# Altium schematic sheet Protection_logic.SchDoc(Protection_logic)
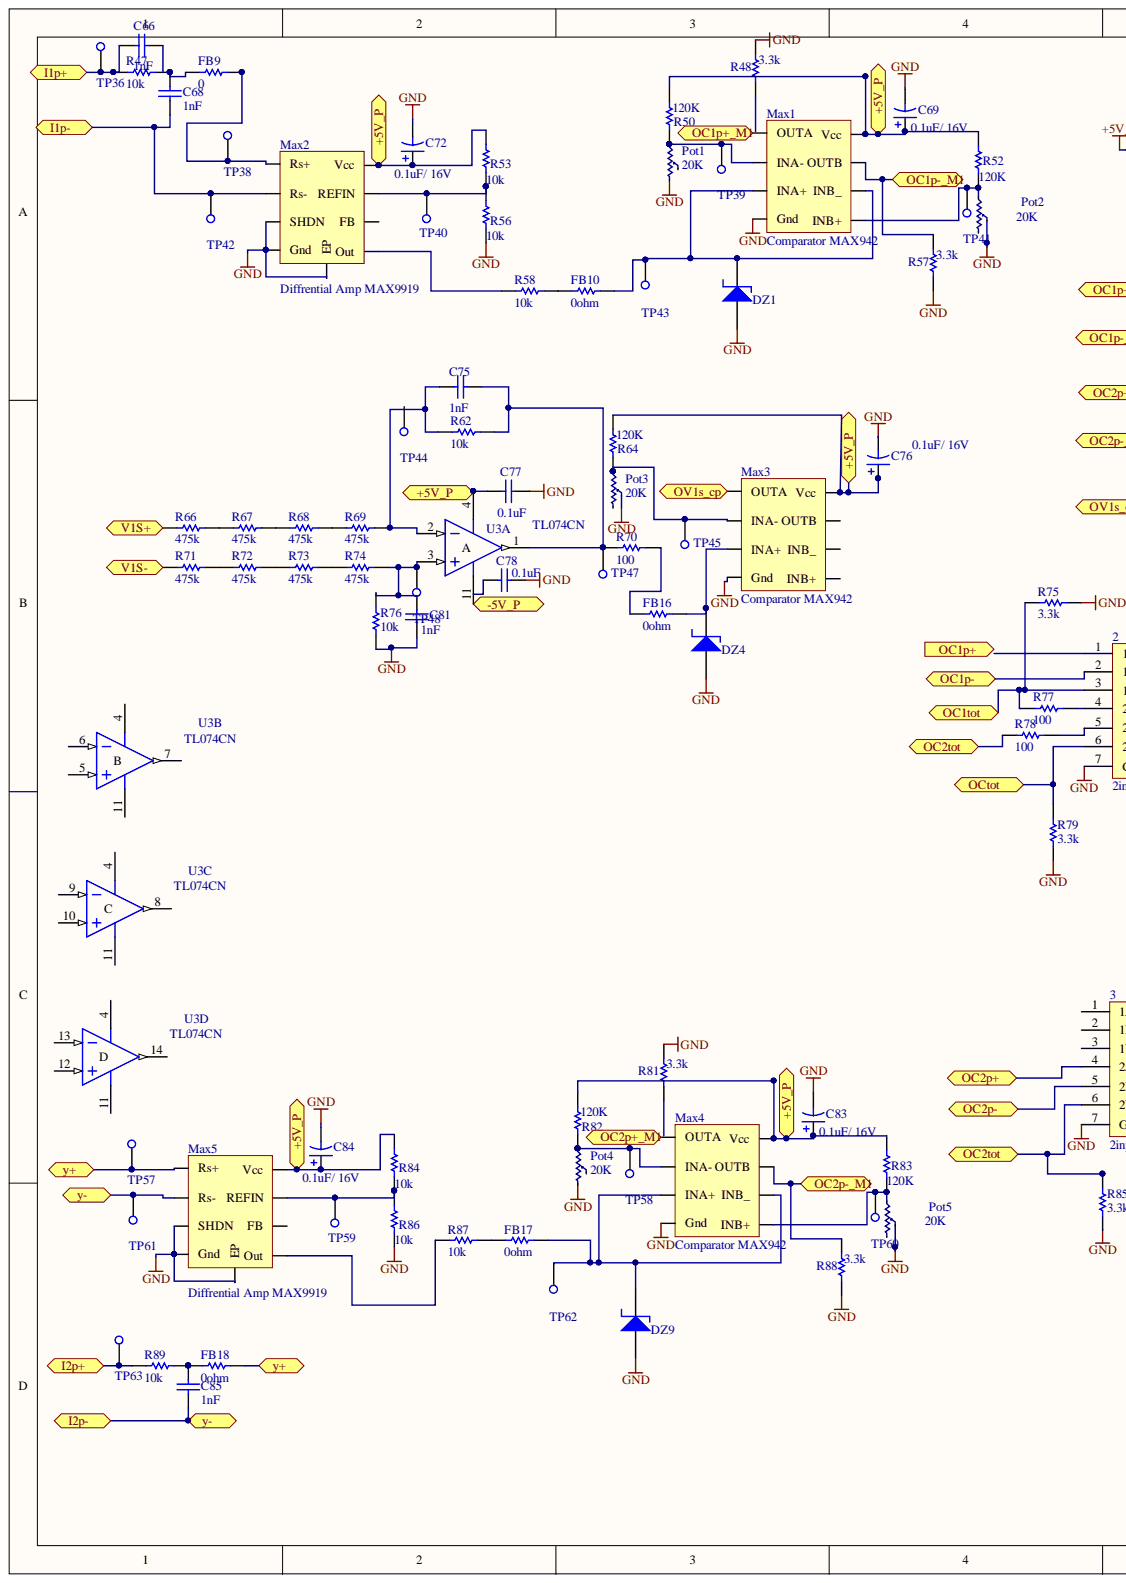
[diagram p0: Protection_logic.SchDoc, left]
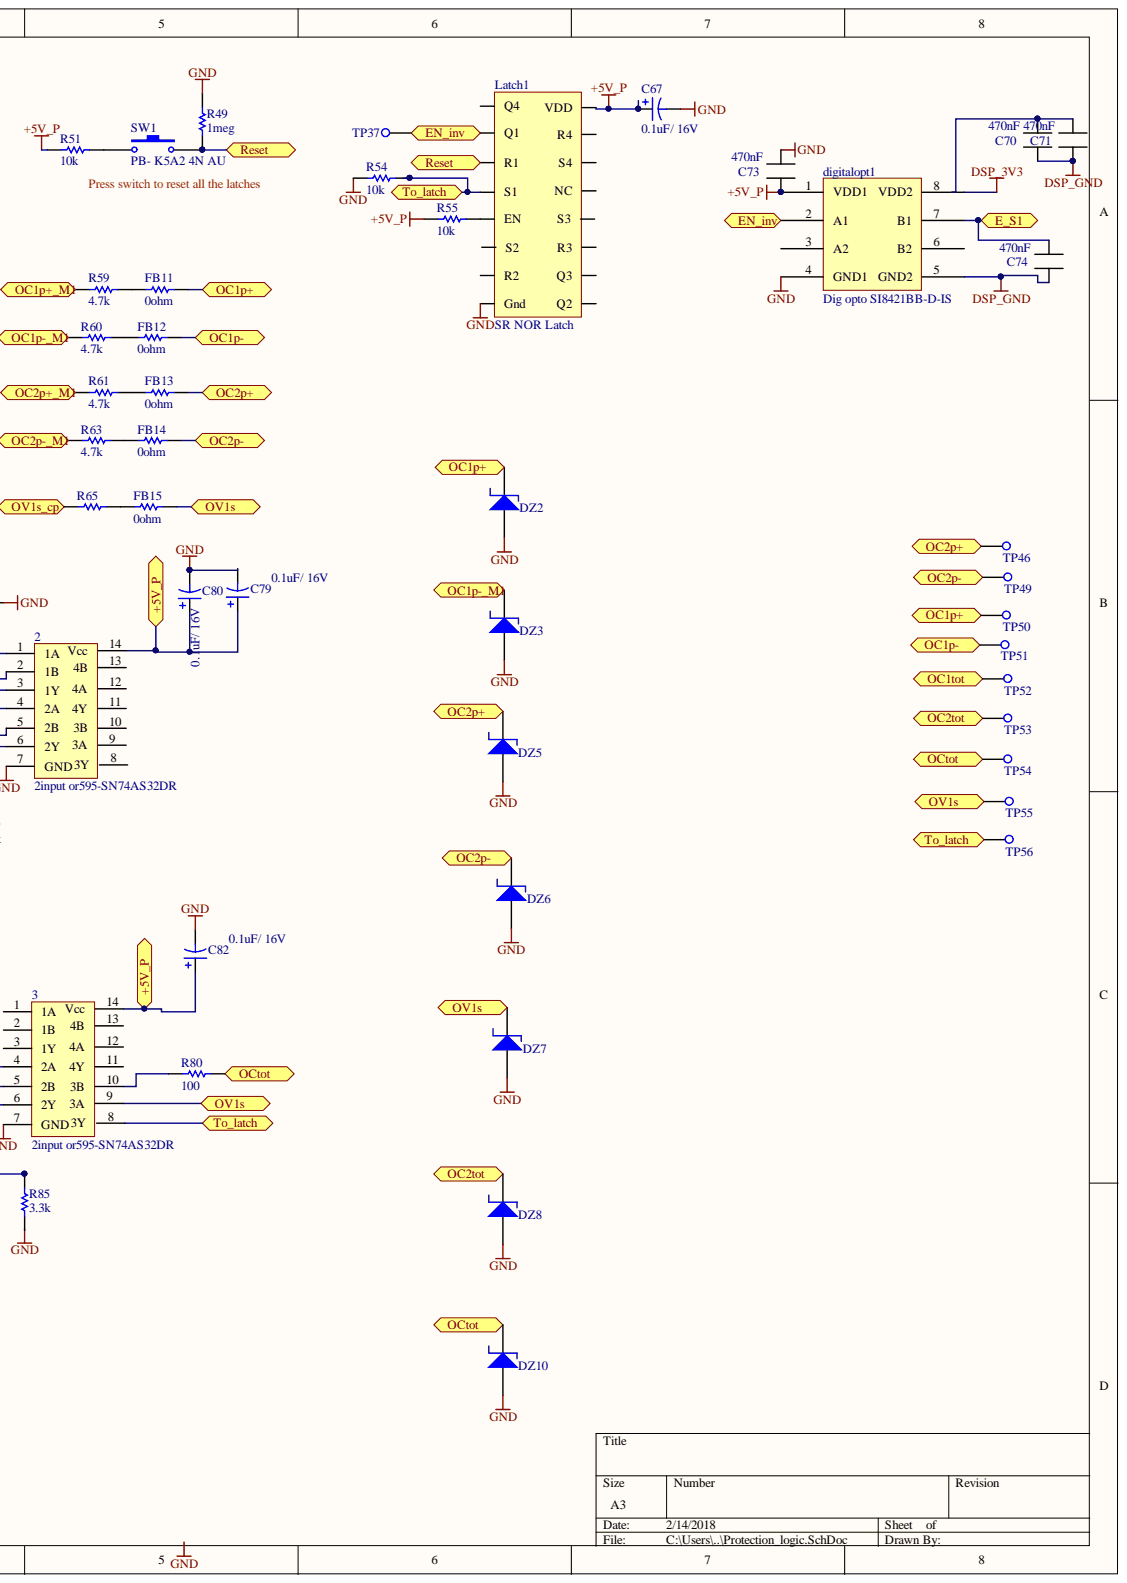
[diagram p1: Protection_logic.SchDoc, right]

## Parts
2  2input or595-SN74AS32DR  [2inputor]
3  2input or595-SN74AS32DR  [2inputor]
C66  value 1nF  [C1206]  (hidden comment: 16V)
C67  value 0.1uF/ 16V  [C0805]  (hidden comment: Cap Pol3)
C68  value 1nF  [C1206]  (hidden comment: 16V)
C69  value 0.1uF/ 16V  [C0805]  (hidden comment: Cap Pol3)
C70  100nF  [Cap_SMD]  (value not drawn)
C71  100nF  [Cap_SMD]  (value not drawn)
C72  value 0.1uF/ 16V  [C0805]  (hidden comment: Cap Pol3)
C73  100nF  [Cap_SMD]  (value not drawn)
C74  100nF  [Cap_SMD]  (value not drawn)
C75  value 1nF  [C1206]  (hidden comment: 16V)
C76  value 0.1uF/ 16V  [C0805]  (hidden comment: Cap Pol3)
C77  value 0.1uF  [C1206]  (hidden comment: 16V)
C78  value 0.1uF  [C1206]  (hidden comment: 16V)
C79  value 0.1uF/ 16V  [C0805]  (hidden comment: Cap Pol3)
C80  value 0.1uF/ 16V  [C0805]  (hidden comment: Cap Pol3)
C81  value 1nF  [C1206]  (hidden comment: 16V)
C82  value 0.1uF/ 16V  [C0805]  (hidden comment: Cap Pol3)
C83  value 0.1uF/ 16V  [C0805]  (hidden comment: Cap Pol3)
C84  value 0.1uF/ 16V  [C0805]  (hidden comment: Cap Pol3)
C85  value 1nF  [C1206]  (hidden comment: 16V)
digitalopt1  Dig opto SI8421BB-D-IS  [SO8 TS27l2]
DZ1  MMSZ5221BS  [MMSZ5221BS]  (value not drawn)
DZ2  MMSZ5221BS  [MMSZ5221BS]  (value not drawn)
DZ3  MMSZ5221BS  [MMSZ5221BS]  (value not drawn)
DZ4  MMSZ5221BS  [MMSZ5221BS]  (value not drawn)
DZ5  MMSZ5221BS  [MMSZ5221BS]  (value not drawn)
DZ6  MMSZ5221BS  [MMSZ5221BS]  (value not drawn)
DZ7  MMSZ5221BS  [MMSZ5221BS]  (value not drawn)
DZ8  MMSZ5221BS  [MMSZ5221BS]  (value not drawn)
DZ9  MMSZ5221BS  [MMSZ5221BS]  (value not drawn)
DZ10  MMSZ5221BS  [MMSZ5221BS]  (value not drawn)
FB9  res_smd  [res_smd]  (value not drawn)
FB10  res_smd  [res_smd]  (value not drawn)
FB11  res_smd  [res_smd]  (value not drawn)
FB12  res_smd  [res_smd]  (value not drawn)
FB13  res_smd  [res_smd]  (value not drawn)
FB14  res_smd  [res_smd]  (value not drawn)
FB15  res_smd  [res_smd]  (value not drawn)
FB16  res_smd  [res_smd]  (value not drawn)
FB17  res_smd  [res_smd]  (value not drawn)
FB18  res_smd  [res_smd]  (value not drawn)
Latch1  SR NOR Latch  [SR latch]
Max1  Comparator MAX942  [SOIC 8_ no EP]
Max2  Diffrential Amp MAX9919  [SOIC127P600X168_HS-9N]
Max3  Comparator MAX942  [SOIC 8_ no EP]
Max4  Comparator MAX942  [SOIC 8_ no EP]
Max5  Diffrential Amp MAX9919  [SOIC127P600X168_HS-9N]
Pot1  20K  [Pot]
Pot2  20K  [Pot]
Pot3  20K  [Pot]
Pot4  20K  [Pot]
Pot5  20K  [Pot]
R47  res_smd  [res_smd]  (value not drawn)
R48  res_smd  [res_smd]  (value not drawn)
R49  res_smd  [res_smd]  (value not drawn)
R50  res_smd  [res_smd]  (value not drawn)
R51  res_smd  [res_smd]  (value not drawn)
R52  res_smd  [res_smd]  (value not drawn)
R53  res_smd  [res_smd]  (value not drawn)
R54  res_smd  [res_smd]  (value not drawn)
R55  res_smd  [res_smd]  (value not drawn)
R56  res_smd  [res_smd]  (value not drawn)
R57  res_smd  [res_smd]  (value not drawn)
R58  res_smd  [res_smd]  (value not drawn)
R59  res_smd  [res_smd]  (value not drawn)
R60  res_smd  [res_smd]  (value not drawn)
R61  res_smd  [res_smd]  (value not drawn)
R62  res_smd  [res_smd]  (value not drawn)
R63  res_smd  [res_smd]  (value not drawn)
R64  res_smd  [res_smd]  (value not drawn)
R65  res_smd  [res_smd]  (value not drawn)
R66  res_smd  [res_smd]  (value not drawn)
R67  res_smd  [res_smd]  (value not drawn)
R68  res_smd  [res_smd]  (value not drawn)
R69  res_smd  [res_smd]  (value not drawn)
R70  res_smd  [res_smd]  (value not drawn)
R71  res_smd  [res_smd]  (value not drawn)
R72  res_smd  [res_smd]  (value not drawn)
R73  res_smd  [res_smd]  (value not drawn)
R74  res_smd  [res_smd]  (value not drawn)
R75  res_smd  [res_smd]  (value not drawn)
R76  res_smd  [res_smd]  (value not drawn)
R77  res_smd  [res_smd]  (value not drawn)
R78  res_smd  [res_smd]  (value not drawn)
R79  res_smd  [res_smd]  (value not drawn)
R80  res_smd  [res_smd]  (value not drawn)
R81  res_smd  [res_smd]  (value not drawn)
R82  res_smd  [res_smd]  (value not drawn)
R83  res_smd  [res_smd]  (value not drawn)
R84  res_smd  [res_smd]  (value not drawn)
R85  res_smd  [res_smd]  (value not drawn)
R86  res_smd  [res_smd]  (value not drawn)
R87  res_smd  [res_smd]  (value not drawn)
R88  res_smd  [res_smd]  (value not drawn)
R89  res_smd  [res_smd]  (value not drawn)
SW1  PB- K5A2 4N AU  [Push Button _New]
TP36  Test Point  [Test Point]  (value not drawn)
TP37  Test Point  [Test Point]  (value not drawn)
TP38  Test Point  [Test Point]  (value not drawn)
TP39  Test Point  [Test Point]  (value not drawn)
TP40  Test Point  [Test Point]  (value not drawn)
TP41  Test Point  [Test Point]  (value not drawn)
TP42  Test Point  [Test Point]  (value not drawn)
TP43  Test Point  [Test Point]  (value not drawn)
TP44  Test Point  [Test Point]  (value not drawn)
TP45  Test Point  [Test Point]  (value not drawn)
TP46  Test Point  [Test Point]  (value not drawn)
TP47  Test Point  [Test Point]  (value not drawn)
TP48  Test Point  [Test Point]  (value not drawn)
TP49  Test Point  [Test Point]  (value not drawn)
TP50  Test Point  [Test Point]  (value not drawn)
TP51  Test Point  [Test Point]  (value not drawn)
TP52  Test Point  [Test Point]  (value not drawn)
TP53  Test Point  [Test Point]  (value not drawn)
TP54  Test Point  [Test Point]  (value not drawn)
TP55  Test Point  [Test Point]  (value not drawn)
TP56  Test Point  [Test Point]  (value not drawn)
TP57  Test Point  [Test Point]  (value not drawn)
TP58  Test Point  [Test Point]  (value not drawn)
TP59  Test Point  [Test Point]  (value not drawn)
TP60  Test Point  [Test Point]  (value not drawn)
TP61  Test Point  [Test Point]  (value not drawn)
TP62  Test Point  [Test Point]  (value not drawn)
TP63  Test Point  [Test Point]  (value not drawn)
U3  TL074CN  [DIP14]  (parts A,B,C,D)

## Ports
+5V_P, -5V_P, E_S1, EN_inv, I1p+, I1p-, I2p+, I2p-, OC1p+, OC1p+_M1, OC1p-, OC1p-_M1, OC1tot, OC2p+, OC2p+_M1, OC2p-, OC2p-_M1, OC2tot, OCtot, OV1s, OV1s_cp, Reset, To_latch, V1S+, V1S-, y+, y-

## Nets
+5V_P: C67.1 C73.2 digitalopt1.1(VDD1) Latch1.16(VDD) R51.2 R55.2
DSP_3V3: digitalopt1.8(VDD2)
DSP_GND: C70.2 C71.2 C74.2 digitalopt1.5(GND2)
GND: 2.7(GND) 3.7(GND) C67.2 C69.2 C72.2 C73.1 C76.2 C77.1 C78.1 C79.2 C80.2 C81.2 C82.2 C83.2 C84.2 digitalopt1.4(GND1) DZ1.2 DZ2.2 DZ3.2 DZ4.2 DZ5.2 DZ6.2 DZ7.2 DZ8.2 DZ9.2 DZ10.2 Latch1.8(Gnd) Max1.4(Gnd) Max2.3(SHDN) Max2.4(Gnd) Max2.9(EP) Max3.4(Gnd) Max4.4(Gnd) Max5.3(SHDN) Max5.4(Gnd) Max5.9(EP) Pot1.3 Pot2.2 Pot3.2 Pot4.3 Pot5.2 R48.2 R49.1 R54.2 R56.1 R57.1 R75.2 R76.2 R79.1 R81.2 R86.1 R88.1
E_S1: C74.1 digitalopt1.7(B1) ; port E_S1
EN_inv: digitalopt1.2(A1) Latch1.2(Q1) TP37.1 ; port EN_inv
OC1tot: 2.3(1Y) R75.1 R77.2 TP52.1 ; port OC1tot
OC2tot: 3.6(2Y) DZ8.1 R78.2 R85.2 TP53.1 ; port OC2tot
OCtot: 2.6(2Y) DZ10.1 R79.2 R80.1 TP54.1 ; port OCtot
OV1s: 3.9(3A) DZ7.1 FB15.1 TP55.1 ; port OV1s
OV1s_cp: Max3.1(OUTA) R65.2 ; port OV1s_cp
Reset: Latch1.3(R1) R49.2 SW1.2 ; port Reset
To_latch: 3.8(3Y) Latch1.4(S1) R54.1 TP56.1 ; port To_latch
Net2_2: 2.2(1B) FB12.1 TP51.1
Net2_4: 2.4(2A) R77.1
Net2_5: 2.5(2B) R78.1
Net2_14: 2.14(Vcc) 3.14(Vcc) C69.1 C72.1 C76.1 C77.2 C79.1 C80.1 C82.1 C83.1 C84.1 Max1.8(Vcc) Max2.8(Vcc) Max3.8(Vcc) Max4.8(Vcc) Max5.8(Vcc) R50.2 R52.2 R53.2 R64.2 R82.2 R83.2 R84.2 U3.4
Net3_4: 3.4(2A) DZ5.1 FB13.1 TP46.1
Net3_5: 3.5(2B) DZ6.1 FB14.1 TP49.1
Net3_10: 3.10(3B) R80.2
NetC66_1: C66.1 R47.2 TP36.1
NetC68_1: C68.1 FB9.2 R47.1
NetC68_2: C68.2 Max2.2(Rs-) TP42.1
NetC70_1: C70.1 C71.1
NetC75_1: C75.1 R62.1 R70.2 TP47.1 U3.1
NetC75_2: C75.2 R62.2 R69.1 U3.2
NetC81_1: C81.1 R74.1 R76.1 TP48.1 U3.3
NetC85_1: C85.1 FB18.2 R89.1
NetC85_2: C85.2 Max5.2(Rs-) TP61.1
NetDZ1_1: DZ1.1 FB10.1 Max1.3(INA+) Max1.6(INB_) TP43.1
NetDZ2_1: DZ2.1 FB11.1 TP50.1
NetDZ3_1: DZ3.1 Max1.7(OUTB) R57.2 R60.2
NetDZ4_1: DZ4.1 FB16.1 Max3.3(INA+)
NetDZ9_1: DZ9.1 FB17.1 Max4.6(INB_) TP62.1
NetFB9_1: FB9.1 Max2.1(Rs+) TP38.1
NetFB10_2: FB10.2 R58.1
NetFB11_2: FB11.2 R59.1
NetFB12_2: FB12.2 R60.1
NetFB13_2: FB13.2 R61.1
NetFB14_2: FB14.2 R63.1
NetFB15_2: FB15.2 R65.1
NetFB16_2: FB16.2 R70.1
NetFB17_2: FB17.2 R87.1
NetFB18_1: FB18.1 Max5.1(Rs+) TP57.1
NetLatch1_5: Latch1.5(EN) R55.1
NetMax1_1: Max1.1(OUTA) R59.2
NetMax1_2: Max1.2(INA-) Pot1.1 R50.1 TP39.1
NetMax1_5: Max1.5(INB+) Pot2.1 R52.1 TP41.1
NetMax2_5: Max2.5(Out) R58.2
NetMax2_7: Max2.7(REFIN) R53.1 R56.2 TP40.1
NetMax3_2: Max3.2(INA-) Pot3.1 R64.1 TP45.1
NetMax4_1: Max4.1(OUTA) R61.2
NetMax4_2: Max4.2(INA-) Pot4.1 R82.1 TP58.1
NetMax4_5: Max4.5(INB+) Pot5.1 R83.1 TP60.1
NetMax4_7: Max4.7(OUTB) R63.2 R88.2
NetMax5_5: Max5.5(Out) R87.2
NetMax5_7: Max5.7(REFIN) R84.1 R86.2 TP59.1
NetR51_1: R51.1 SW1.1
NetR66_1: R66.1 R67.2
NetR66_2: R66.2
NetR67_1: R67.1 R68.2
NetR68_1: R68.1 R69.2
NetR71_1: R71.1 R72.2
NetR71_2: R71.2
NetR72_1: R72.1 R73.2
NetR73_1: R73.1 R74.2
NetR89_2: R89.2 TP63.1
NetU3_11: U3.11

Not connected: 2 1(1A) 8(3Y) 9(3A) 10(3B) 11(4Y) 12(4A) 13(4B); 3 1(1A) 2(1B) 3(1Y) 11(4Y) 12(4A) 13(4B); C66 2; C78 2; digitalopt1 3(A2) 6(B2); Latch1 1(Q4) 6(S2) 7(R2) 9(Q2) 10(Q3) 11(R3) 12(S3) 13(NC) 14(S4) 15(R4); Max2 6(FB); Max3 5(INB+) 6(INB_) 7(OUTB); Max4 3(INA+); Max5 6(FB); Pot1 2; Pot2 3; Pot3 3; Pot4 2; Pot5 3; R48 1; R81 1; R85 1; TP44 1; U3 5 6 7 8 9 10 12 13 14

## Library data of the parts
2 3 = 2 input OR: Component Kind: Standard; Library Name: Gan_cuk converter.SchLib
C66 C68 C75 C77 C78 C81 C85 = Cap Semi: Capacitor (Semiconductor SIM Model); Simulation: CAP; Signal Integrity: Cap Semi; Published: 8-Jun-2000; PackageReference: C1206; PackageDescription: Chip Capacitor; Library Name: Miscellaneous Devices.SchLib; LatestRevisionNote: IPC-7351 Footprint Added.; LatestRevisionDate: 29-May-2009; Component Kind: Standard; Publisher: Altium Limited
C67 C72 C76 C79 C80 C82 C84 = Cap Pol3: Polarized Capacitor (Surface Mount); Simulation: CAP; Signal Integrity: Cap Pol; Published: 8-Jun-2000; PackageReference: C0805; PackageDescription: Chip Capacitor; Library Name: Miscellaneous Devices.SchLib; LatestRevisionNote: IPC-7351 Footprint Added.; LatestRevisionDate: 29-May-2009; Component Kind: Standard; Publisher: Altium Limited
C69 C83 = Cap Pol3: Polarized Capacitor (Surface Mount); Value: 0.1uF/ 16V; Simulation: CAP; Signal Integrity: Cap Pol; Published: 8-Jun-2000; PackageReference: C0805; PackageDescription: Chip Capacitor; Library Name: Miscellaneous Devices.SchLib; LatestRevisionNote: IPC-7351 Footprint Added.; LatestRevisionDate: 29-May-2009; Component Kind: Standard; Publisher: Altium Limited
C70 C71 C73 C74 = Cap_SMD: Library Name: Schlib1.SchLib; Component Kind: Standard; : 470nF
digitalopt1 = Digital Isolator: Library Name: control Board.SchLib; Component Kind: Standard
FB9-FB18 R47-R89 = res_smd: smd_res; Library Name: Schlib1.SchLib; Component Kind: Standard
  FB9: : 0
  FB10-FB18: : 0ohm
  R47 R51 R53-R56 R58 R62 R76 R84 R86 R87 R89: : 10k
  R48 R57 R75 R79 R81 R85 R88: : 3.3k
  R49: : 1meg
  R50 R52 R64 R82 R83: : 120K
  R59-R61 R63: : 4.7k
  R66-R69 R71-R74: : 475k
  R70 R77 R78 R80: : 100
Latch1 = SR Latch: CD4043BDR; Component Kind: Standard; Library Name: Gan_cuk converter.SchLib
Max1 Max3 Max4 = Max942: MAX942ESA+; Component Kind: Standard; Library Name: Gan_cuk converter.SchLib
Max2 Max5 = Max9919: MAX9919NASA+; Component Kind: Standard; Library Name: Gan_cuk converter.SchLib
Pot1-Pot5 = TRIM POT: 3296W-1-253LF; Component Kind: Standard; Library Name: Gan_cuk converter.SchLib
SW1 = SW-PB: Switch; Revision: July-2002: Re-released for DXP Platform.; Published: 24-Mar-1999; Library Name: control Board.SchLib; Component Kind: Standard; Publisher: Altium Limited
U3 = TL074J: Low-Noise JFET-Input Operational Amplifier; Simulation: TL074; Published: 16-Jan-2001; PCB3D: J014; PackageVersion: Jun-1999; PackageReference: J014; PackageDescription: DIP; 14 Leads; Row Spacing 7.62 mm; Pitch 2.54 mm; LatestRevisionNote: Stylized 3D Model Added.; LatestRevisionDate: 04-Mar-2005; DatasheetVersion: Aug-1996; ComponentLink2URL: http://www-s.ti.com/sc/ds/tl074.pdf; ComponentLink2Description: Datasheet; ComponentLink1URL: http://www.ti.com/; ComponentLink1Description: Manufacturer Link; Component Kind: Standard; Publisher: Altium Limited; Library Name: Cuk_3Ph_Control.IntLib
DZ1-DZ10 = MMSZ5221BS: Description: MMSZ5221BS; Component Kind: Standard; Library Name: lib_ankit.SchLib
TP36-TP63 = Test Point: Component Kind: Standard; Library Name: Gan_cuk converter.SchLib
